# Altium schematic sheet Analog output.SchDoc
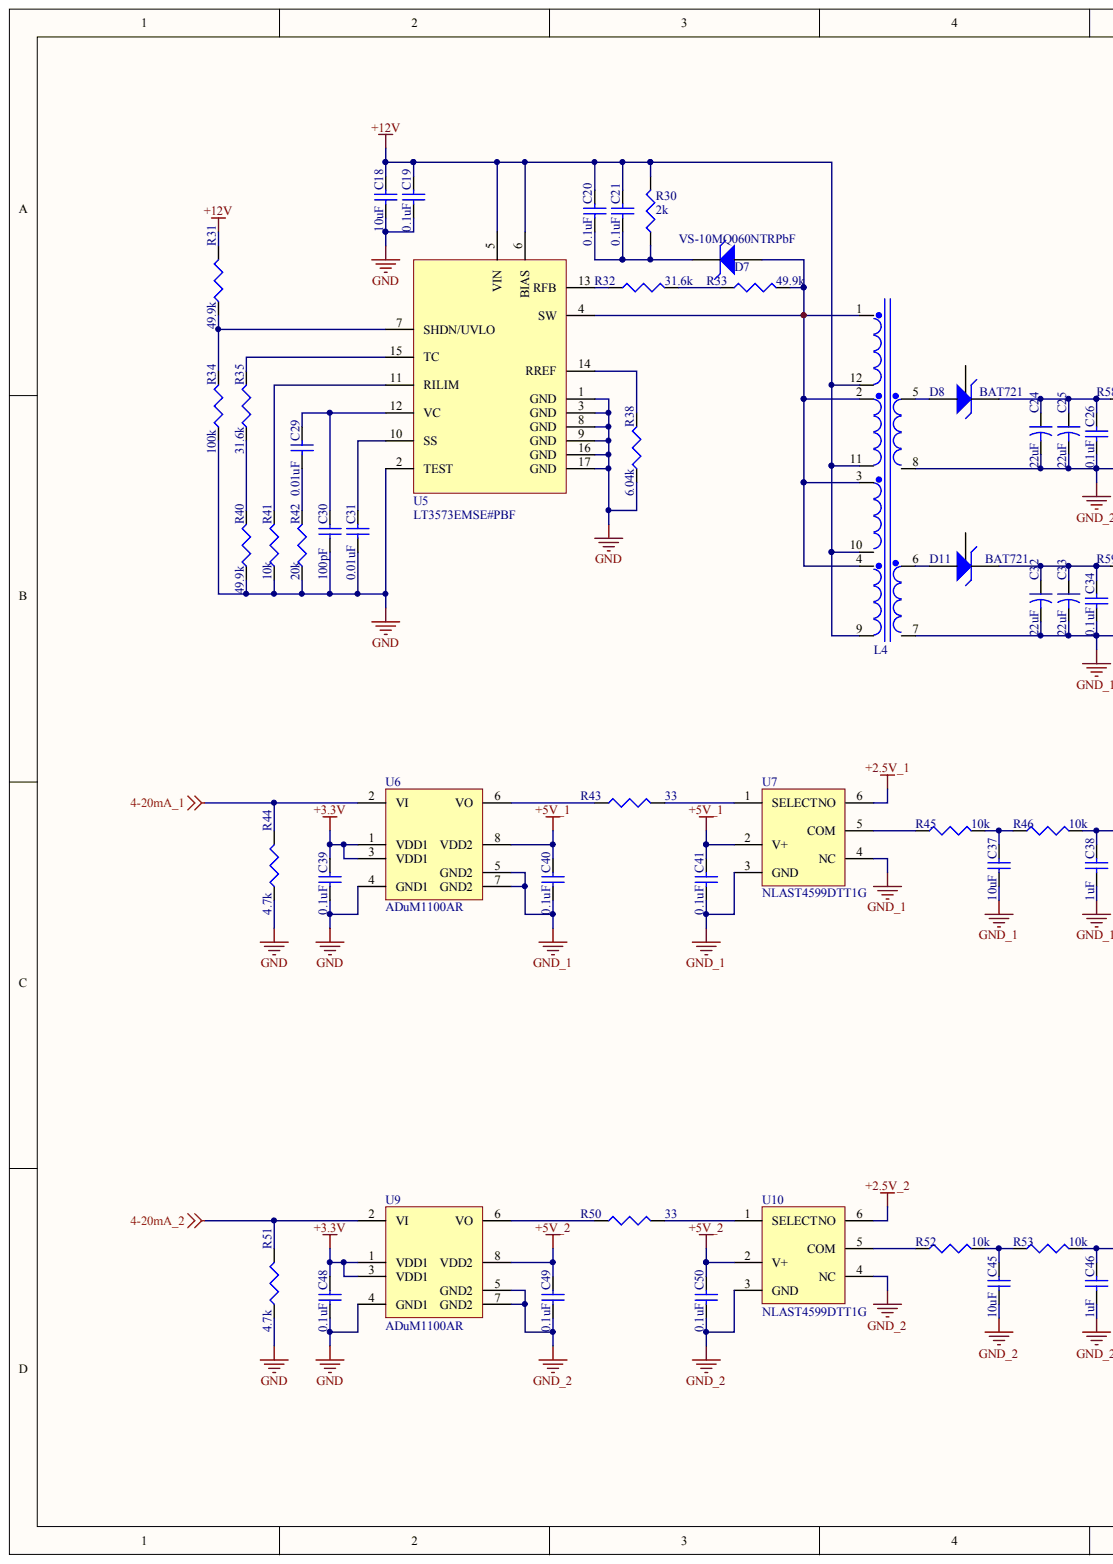
[diagram p0: Analog output.SchDoc, left]
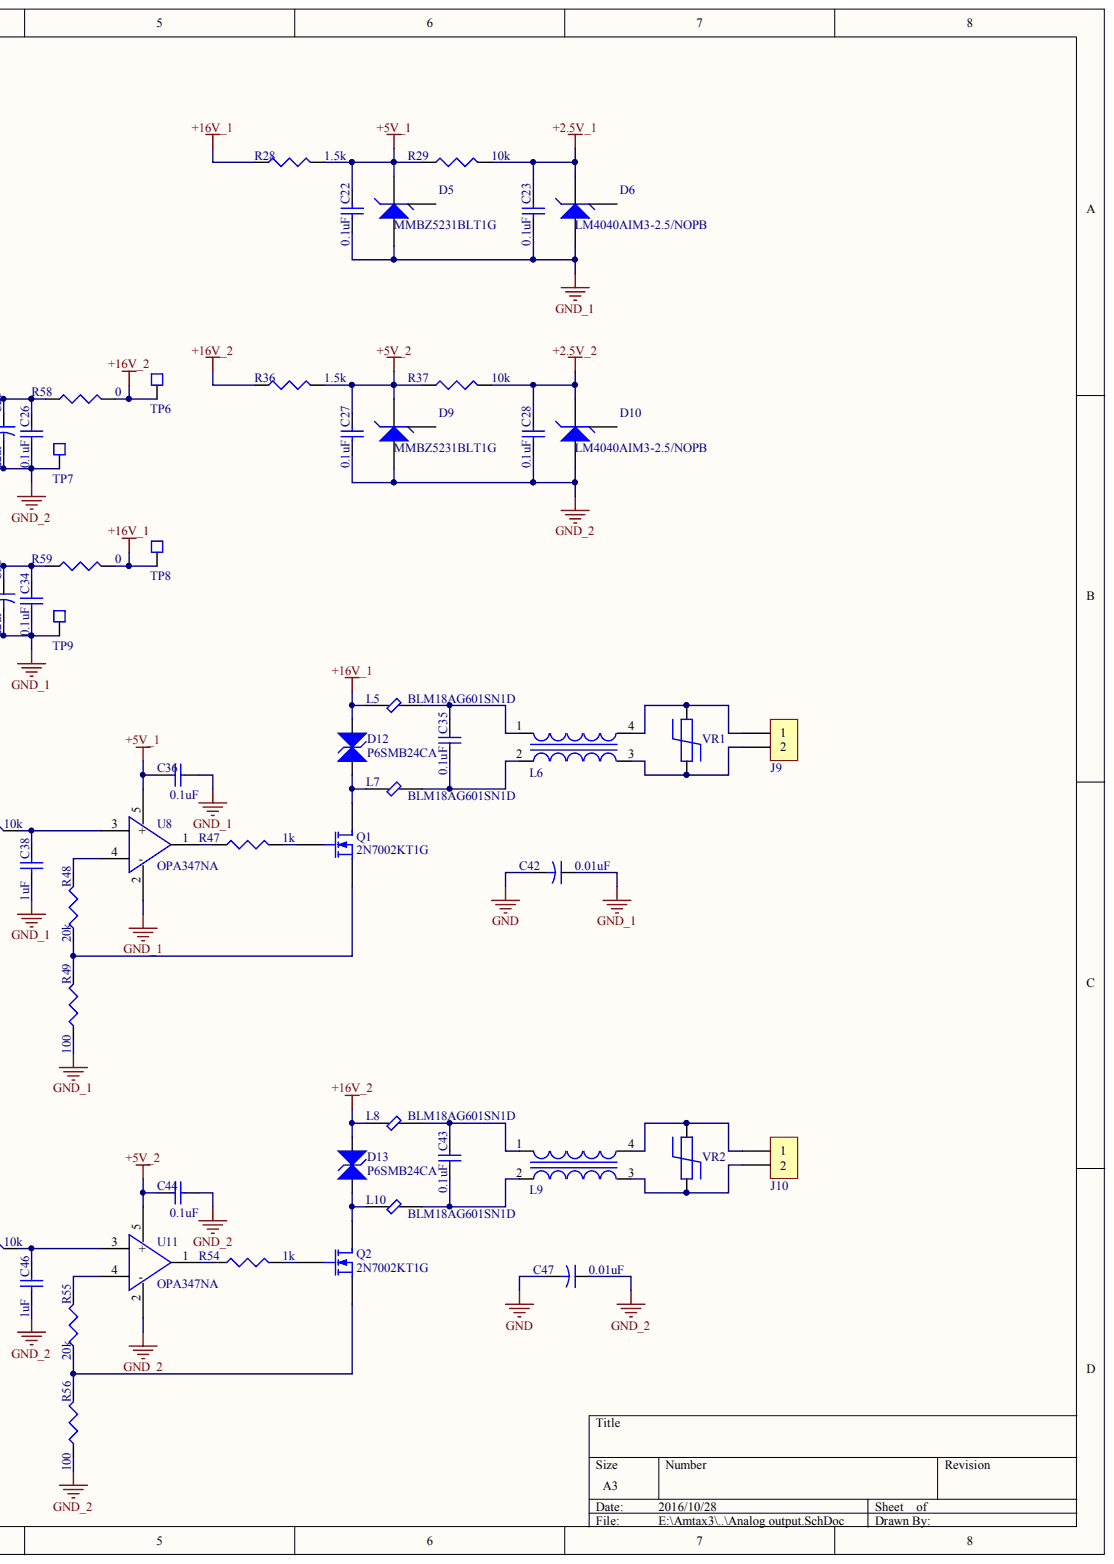
[diagram p1: Analog output.SchDoc, right]

## Parts
C18  value 10uF  [CAP1206(3216)]  (hidden comment: GRM31CR61E106KA12L)
C19  value 0.1uF  [CAP0603(1608)]  (hidden comment: GRM188R71H104KA93D)
C20  value 0.1uF  [CAP0603(1608)]  (hidden comment: GRM188R71H104KA93D)
C21  value 0.1uF  [CAP0603(1608)]  (hidden comment: GRM188R71H104KA93D)
C22  value 0.1uF  [CAP0603(1608)]  (hidden comment: GRM188R71H104KA93D)
C23  value 0.1uF  [CAP0603(1608)]  (hidden comment: GRM188R71H104KA93D)
C24  value 22uF  [CAP1210(3225)]  (hidden comment: GRM32ER71E226KE15L)
C25  value 22uF  [CAP1210(3225)]  (hidden comment: GRM32ER71E226KE15L)
C26  value 0.1uF  [CAP0603(1608)]  (hidden comment: GRM188R71H104KA93D)
C27  value 0.1uF  [CAP0603(1608)]  (hidden comment: GRM188R71H104KA93D)
C28  value 0.1uF  [CAP0603(1608)]  (hidden comment: GRM188R71H104KA93D)
C29  value 0.01uF  [CAP0603(1608)]  (hidden comment: GRM188R71H103KA01D)
C30  value 100pF  [CAP0603(1608)]  (hidden comment: GRM1885C1H101JA01D)
C31  value 0.01uF  [CAP0603(1608)]  (hidden comment: GRM188R71H103KA01D)
C32  value 22uF  [CAP1210(3225)]  (hidden comment: GRM32ER71E226KE15L)
C33  value 22uF  [CAP1210(3225)]  (hidden comment: GRM32ER71E226KE15L)
C34  value 0.1uF  [CAP0603(1608)]  (hidden comment: GRM188R71H104KA93D)
C35  value 0.1uF  [CAP0603(1608)]  (hidden comment: GRM188R71H104KA93D)
C36  value 0.1uF  [CAP0603(1608)]  (hidden comment: GRM188R71H104KA93D)
C37  value 10uF  [CAP1206(3216)]  (hidden comment: GRM31CR61E106KA12L)
C38  value 1uF  [CAP0805(2012)]  (hidden comment: GRM21BR71H105KA12L)
C39  value 0.1uF  [CAP0603(1608)]  (hidden comment: GRM188R71H104KA93D)
C40  value 0.1uF  [CAP0603(1608)]  (hidden comment: GRM188R71H104KA93D)
C41  value 0.1uF  [CAP0603(1608)]  (hidden comment: GRM188R71H104KA93D)
C42  value 0.01uF  [CAP1206(3216)]  (hidden comment: GRJ31BR72J103KWJ1)
C43  value 0.1uF  [CAP0603(1608)]  (hidden comment: GRM188R71H104KA93D)
C44  value 0.1uF  [CAP0603(1608)]  (hidden comment: GRM188R71H104KA93D)
C45  value 10uF  [CAP1206(3216)]  (hidden comment: GRM31CR61E106KA12L)
C46  value 1uF  [CAP0805(2012)]  (hidden comment: GRM21BR71H105KA12L)
C47  value 0.01uF  [CAP1206(3216)]  (hidden comment: GRJ31BR72J103KWJ1)
C48  value 0.1uF  [CAP0603(1608)]  (hidden comment: GRM188R71H104KA93D)
C49  value 0.1uF  [CAP0603(1608)]  (hidden comment: GRM188R71H104KA93D)
C50  value 0.1uF  [CAP0603(1608)]  (hidden comment: GRM188R71H104KA93D)
D5  MMBZ5231BLT1G  [SOT23(MMBZ52xxBLT1G)]
D6  LM4040AIM3-2.5/NOPB  [SOT23(LM4040AIM3-2.5/NOPB)]
D7  VS-10MQ060NTRPbF  [VS-10MQ060NTRPbF]
D8  BAT721  [SOT-23(BAT721S)-F]
D9  MMBZ5231BLT1G  [SOT23(MMBZ52xxBLT1G)]
D10  LM4040AIM3-2.5/NOPB  [SOT23(LM4040AIM3-2.5/NOPB)]
D11  BAT721  [SOT-23(BAT721S)-F]
D12  P6SMB24CA  [P6SMB24CA]
D13  P6SMB24CA  [P6SMB24CA]
J9  691322310002  [WE 3.81mm Pitch Horizontal 2Pin]  (value not drawn)
J10  691322310002  [WE 3.81mm Pitch Horizontal 2Pin]  (value not drawn)
L4  VPH3-0138-R  [VPH3-0138-R]  (value not drawn)
L5  BLM18AG601SN1D  [BLM18B]
L6  CM0805A371R-10  [CM0805A371R-10]  (value not drawn)
L7  BLM18AG601SN1D  [BLM18B]
L8  BLM18AG601SN1D  [BLM18B]
L9  CM0805A371R-10  [CM0805A371R-10]  (value not drawn)
L10  BLM18AG601SN1D  [BLM18B]
Q1  2N7002KT1G  [SOT23(TO-236)]
Q2  2N7002KT1G  [SOT23(TO-236)]
R28  value 1.5k  [RES0603(1608)]  (hidden comment: RK73B1JTTD152J)
R29  value 10k  [RES0603(1608)]  (hidden comment: RK73B1JTTD103J)
R30  value 2k  [RES0603(1608)]  (hidden comment: RK73B1JTTD202J)
R31  value 49.9k  [RES0603(1608)]  (hidden comment: ERJ3EKF4992V)
R32  value 31.6k  [RES0603(1608)]  (hidden comment: ERJ3EKF3162V)
R33  value 49.9k  [RES0603(1608)]  (hidden comment: ERJ3EKF4992V)
R34  value 100k  [RES0603(1608)]  (hidden comment: RK73H1JTTD1003F)
R35  value 31.6k  [RES0603(1608)]  (hidden comment: ERJ3EKF3162V)
R36  value 1.5k  [RES0603(1608)]  (hidden comment: RK73B1JTTD152J)
R37  value 10k  [RES0603(1608)]  (hidden comment: RK73B1JTTD103J)
R38  value 6.04k  [RES0603(1608)]  (hidden comment: ERJ3EKF6041V)
R40  value 49.9k  [RES0603(1608)]  (hidden comment: ERJ3EKF4992V)
R41  value 10k  [RES0603(1608)]  (hidden comment: RK73B1JTTD103J)
R42  value 20k  [RES0603(1608)]  (hidden comment: CRCW060320K0FKEA)
R43  value 33  [RES0603(1608)]  (hidden comment: RK73B1JTTD330J)
R44  value 4.7k  [RES0603(1608)]  (hidden comment: RK73B1JTTD472J)
R45  value 10k  [RES0603(1608)]  (hidden comment: RK73B1JTTD103J)
R46  value 10k  [RES0603(1608)]  (hidden comment: RK73B1JTTD103J)
R47  value 1k  [RES0603(1608)]  (hidden comment: RK73B1JTTD102J)
R48  value 20k  [RES0603(1608)]  (hidden comment: CRCW060320K0FKEA)
R49  value 100  [RES0805(2012)]  (hidden comment: ERA6AEB101V)
R50  value 33  [RES0603(1608)]  (hidden comment: RK73B1JTTD330J)
R51  value 4.7k  [RES0603(1608)]  (hidden comment: RK73B1JTTD472J)
R52  value 10k  [RES0603(1608)]  (hidden comment: RK73B1JTTD103J)
R53  value 10k  [RES0603(1608)]  (hidden comment: RK73B1JTTD103J)
R54  value 1k  [RES0603(1608)]  (hidden comment: RK73B1JTTD102J)
R55  value 20k  [RES0603(1608)]  (hidden comment: CRCW060320K0FKEA)
R56  value 100  [RES0805(2012)]  (hidden comment: ERA6AEB101V)
R58  value 0  [RES0805(2012)]  (hidden comment: RK73Z2ATTD)
R59  value 0  [RES0805(2012)]  (hidden comment: RK73Z2ATTD)
TP6  TEST-1(R)  [TEST PIN]  (value not drawn)
TP7  TEST-1-BK  [TEST PIN]  (value not drawn)
TP8  TEST-1(R)  [TEST PIN]  (value not drawn)
TP9  TEST-1-BK  [TEST PIN]  (value not drawn)
U5  LT3573EMSE#PBF  [MSOP-16(LT3573)]
U6  ADuM1100AR  [SOIC-8(ADuM1100AR)]
U7  NLAST4599DTT1G  [TSOP(NLAST4599DTT1G)]
U8  OPA347NA  [SOT23-5(OPA347NA)]
U9  ADuM1100AR  [SOIC-8(ADuM1100AR)]
U10  NLAST4599DTT1G  [TSOP(NLAST4599DTT1G)]
U11  OPA347NA  [SOT23-5(OPA347NA)]
VR1  V18MLA0805H  [V18MLA0805H]  (value not drawn)
VR2  V18MLA0805H  [V18MLA0805H]  (value not drawn)

## Nets
4-20MA_1: R44.2 U6.2(VI)
4-20MA_2: R51.2 U9.2(VI)
+2.5V_1: C23.2 D6.1 R29.2 U7.6(NO)
+2.5V_2: C28.2 D10.1 R37.2 U10.6(NO)
+3.3V: C39.2 C48.2 U6.1(VDD1) U6.3(VDD1) U9.1(VDD1) U9.3(VDD1)
+5V_1: C22.2 C36.2 C40.2 C41.2 D5.3 R28.2 R29.1 U6.8(VDD2) U7.2(V+) U8.5(+)
+5V_2: C27.2 C44.2 C49.2 C50.2 D9.3 R36.2 R37.1 U9.8(VDD2) U10.2(V+) U11.5(+)
+12V: C18.2 C19.2 C20.2 C21.2 L4.9 L4.10 L4.11 L4.12 R30.2 R31.2 U5.5(VIN) U5.6(BIAS)
+16V_1: D12.2 L5.1 R28.1 R59.2 TP8.1
+16V_2: D13.2 L8.1 R36.1 R58.2 TP6.1
GND: C18.1 C19.1 C30.2 C31.1 C39.1 C42.2 C47.2 C48.1 R34.1 R38.1 R40.1 R41.1 R42.1 R44.1 R51.1 U5.1(GND) U5.2(TEST) U5.3(GND) U5.8(GND) U5.9(GND) U5.16(GND) U5.17(GND) U6.4(GND1) U9.4(GND1)
GND_1: C22.1 C23.1 C32.2 C33.2 C34.1 C36.1 C37.1 C38.1 C40.1 C41.1 C42.1 D5.1 D6.2 L4.7 R49.1 TP9.1 U6.5(GND2) U6.7(GND2) U7.3(GND) U7.4(NC) U8.2
GND_2: C24.2 C25.2 C26.1 C27.1 C28.1 C44.1 C45.1 C46.1 C47.1 C49.1 C50.1 D9.1 D10.2 L4.8 R56.1 TP7.1 U9.5(GND2) U9.7(GND2) U10.3(GND) U10.4(NC) U11.2
NetC20_1: C20.1 C21.1 D7.2 R30.1
NetC24_1: C24.1 C25.1 C26.2 D8.3 R58.1
NetC29_1: C29.1 R42.2
NetC29_2: C29.2 C30.1 U5.12(VC)
NetC31_2: C31.2 U5.10(SS)
NetC32_1: C32.1 C33.1 C34.2 D11.3 R59.1
NetC35_1: C35.1 L6.2 L7.2
NetC35_2: C35.2 L5.2 L6.1
NetC37_2: C37.2 R45.2 R46.1
NetC38_2: C38.2 R46.2 U8.3
NetC43_1: C43.1 L9.2 L10.2
NetC43_2: C43.2 L8.2 L9.1
NetC45_2: C45.2 R52.2 R53.1
NetC46_2: C46.2 R53.2 U11.3
NetD7_1: D7.1 L4.1 L4.2 L4.3 L4.4 R33.2 U5.4(SW)
NetD8_1: D8.1 L4.5
NetD11_1: D11.1 L4.6
NetD12_1: D12.1 L7.1 Q1.3
NetD13_1: D13.1 L10.1 Q2.3
NetJ9_1: J9.1 L6.4 VR1.2
NetJ9_2: J9.2 L6.3 VR1.1
NetJ10_1: J10.1 L9.4 VR2.2
NetJ10_2: J10.2 L9.3 VR2.1
NetQ1_1: Q1.1 R47.2
NetQ1_2: Q1.2 R48.1 R49.2
NetQ2_1: Q2.1 R54.2
NetQ2_2: Q2.2 R55.1 R56.2
NetR31_1: R31.1 R34.2 U5.7(SHDN/UVLO)
NetR32_1: R32.1 U5.13(RFB)
NetR32_2: R32.2 R33.1
NetR35_1: R35.1 R40.2
NetR35_2: R35.2 U5.15(TC)
NetR38_2: R38.2 U5.14(RREF)
NetR41_2: R41.2 U5.11(RILIM)
NetR43_1: R43.1 U6.6(VO)
NetR43_2: R43.2 U7.1(SELECT)
NetR45_1: R45.1 U7.5(COM)
NetR47_1: R47.1 U8.1
NetR48_2: R48.2 U8.4(-)
NetR50_1: R50.1 U9.6(VO)
NetR50_2: R50.2 U10.1(SELECT)
NetR52_1: R52.1 U10.5(COM)
NetR54_1: R54.1 U11.1
NetR55_2: R55.2 U11.4(-)

Not connected: D5 2; D6 3; D8 2; D9 2; D10 3; D11 2

## Library data of the parts
C18 C37 C45 = CAP1206 10MFD 25VDC X5R: Ceramic Capacitor CAP1206 10MFD 25VDC X5R; Vendor Name: MURATA; Component Kind: Standard; MPN: GRM31CR61E106KA12L; Library Name: dosing board.SchLib
C19-C23 C26-C28 C34-C36 C39-C41 C43 C44 C48-C50 = CAP0603 0.1MFD 50VDC X7R: Ceramic Capacitor CAP0603 0.1MFD 50VDC X7R; Vendor Name: MURATA; Component Kind: Standard; MPN: GRM188R71H104KA93D; Library Name: dosing board.SchLib
C24 C25 C32 C33 = CAP1210 22MFD 25VDC X7R: Ceramic Capacitor CAP1210 22MFD 25VDC X7R; Vendor Name: MURATA; Component Kind: Standard; MPN: GRM32ER71E226KE15L; Library Name: dosing board.SchLib
C29 C31 = CAP0603 0.01MFD 50VDC X7R: Ceramic Capacitor CAP0603 0.01MFD 50VDC X7R; Vendor Name: MURATA; Component Kind: Standard; MPN: GRM188R71H103KA01D; Library Name: dosing board.SchLib
C30 = CAP0603 100PFD 50VDC COG: Ceramic Capacitor CAP0603 100PFD 50VDC COG; Vendor Name: MURATA; Component Kind: Standard; MPN: GRM1885C1H101JA01D; Library Name: dosing board.SchLib
C38 C46 = CAP0805 1MFD 50VDC X7R: Ceramic Capacitor CAP0805 1MFD 50VDC X7R; Vendor Name: MURATA; Component Kind: Standard; MPN: GRM21BR71H105KA12L; Library Name: dosing board.SchLib
C42 C47 = CAP1206 0.01MFD 630VDC X7R: Ceramic Capacitor CAP1206 0.01MFD 630VDC X7R; Vendor Name: MURATA; Component Kind: Standard; MPN: GRJ31BR72J103KWJ1; Library Name: dosing board.SchLib
D5 D9 = MMBZ5231BLT1G: Zener Voltage Regulators; Vendor Name: ON SEMICONDUCTOR; Component Kind: Standard; MPN: MMBZ5231BLT1G; Library Name: dosing board.SchLib
D6 D10 = LM4040AIM3-2.5/NOPB: N/-Q1 Precision Micropower Shunt Voltage Reference; Vendor Name: Texas Instruments; Component Kind: Standard; MPN: LM4040AIM3-2.5/NOPB; Library Name: dosing board.SchLib
D7 = VS-10MQ060NTRPbF: High Performance Schottky Rectifier, 1 A; Vendor Name: VISHAY; Component Kind: Standard; MPN: VS-10MQ060NTRPbF; Library Name: dosing board.SchLib
D8 D11 = BAT721: Single Schottky Diode, V=40V, I=200mA; Vendor Name: NXP; Component Kind: Standard; MPN: BAT721; Library Name: dosing board.SchLib
D12 D13 = P6SMB24CA: 600 Watt Peak Power Zener  Transient Voltage  Suppressors; MPN: P6SMB24CAT3G; Vendor Name: On Semi; Component Kind: Standard; Library Name: dosing board.SchLib
J9 J10 = 691322310002: 2Pin，3.81mm Pitch，Connector; Vendor Name: WE; Component Kind: Standard; MPN: 691322310002; Library Name: dosing board.SchLib
L4 = VPH3-0138-R: VERSA-PAC Inductors and Transformers; Vendor Name: Eaton; Component Kind: Standard; MPN: VPH3-0138-R; Library Name: dosing board.SchLib
L5 L7 L8 L10 = BLM18AG601SN1D: Ferrite Bead for General  Signal Lines; Vendor Name: MURATA; Component Kind: Standard; MPN: BLM18AG601SN1D; Library Name: dosing board.SchLib
L6 L9 = CM0805A371R-10: Common Mode Choke Coil 370ohm, 100mA; Vendor Name: LAIRD TECHNOLOGIES; Component Kind: Standard; MPN: CM0805A371R-10; Library Name: dosing board.SchLib
Q1 Q2 = 2N7002K: N-Channel MOSFET; Vendor Name: ON SEMI; Component Kind: Standard; MPN: 2N7002KT1G; Library Name: dosing board.SchLib
R28 R36 = RES0603, 1.5K OHM 1/10W 5%: Vendor Name: KOA; Component Kind: Standard; Description: RES0603, 1.5K OHM 1/10W 5%; MPN: RK73B1JTTD152J; Library Name: dosing board.SchLib
R29 R37 R41 R45 R46 R52 R53 = RES0603, 10K OHM 1/10W 5%: Vendor Name: KOA; Component Kind: Standard; Description: RES0603, 10K OHM 1/10W 5%; MPN: RK73B1JTTD103J; Library Name: dosing board.SchLib
R30 = RES0603, 2K OHM 1/10W 5%: Vendor Name: KOA; Component Kind: Standard; Description: RES0603, 2K OHM 1/10W 5%; MPN: RK73B1JTTD202J; Library Name: dosing board.SchLib
R31 R33 R40 = RES0603, 49.9k OHM 1/10W 1%: RES0603,49.9k OHM 1/10W 1%; Vendor Name: Panasonic; Component Kind: Standard; MPN: ERJ3EKF4992V; Library Name: dosing board.SchLib
R32 R35 = RES0603, 31.6k OHM 1/10W 1%: RES0603,31.6k OHM 1/10W 1%; Vendor Name: Panasonic; Component Kind: Standard; MPN: ERJ3EKF3162V; Library Name: dosing board.SchLib
R34 = RES0603, 100k OHM 1/10W 1%: Vendor Name: KOA; Component Kind: Standard; Description: RES0603, 100k OHM 1/10W 1%; MPN: RK73H1JTTD1003F; Library Name: dosing board.SchLib
R38 = RES0603, 6.04k OHM 1/10W 1%: RES0603,6.04k OHM 1/10W 1%; Vendor Name: Panasonic; Component Kind: Standard; MPN: ERJ3EKF6041V; Library Name: dosing board.SchLib
R42 R48 R55 = RES0603, 20K OHM 1/10W 5%: RES0603, 20K OHM 1/10W 1%; Vendor Name: VISHAY; Component Kind: Standard; MPN: CRCW060320K0FKEA; Library Name: dosing board.SchLib
R43 R50 = RES0603, 33 OHM 1/10W 5%: RES0603, 33OHM 1/10W 5%; Vendor Name: KOA; Component Kind: Standard; MPN: RK73B1JTTD330J; Library Name: dosing board.SchLib
R44 R51 = RES0603, 4.7K OHM 1/10W 5%: Vendor Name: KOA; Component Kind: Standard; Description: RES0603, 4.7K OHM 1/10W 5%; MPN: RK73B1JTTD472J; Library Name: dosing board.SchLib
R47 R54 = RES0603, 1K OHM 1/10W 5%: Vendor Name: KOA; Component Kind: Standard; Description: RES0603, 1K OHM 1/10W 5%; MPN: RK73B1JTTD102J; Library Name: dosing board.SchLib
R49 R56 = RES0805, 100 OHM 1/8W 0.1%: Vendor Name: PANASONIC; Component Kind: Standard; Description: RES0805, 100 OHM 1/8W 0.1%; MPN: ERA6AEB101V; Library Name: dosing board.SchLib
R58 R59 = RES0805, 0 OHM: Vendor Name: KOA; Component Kind: Standard; Description: RES0805, 0 OHM; MPN: RK73Z2ATTD; Library Name: dosing board.SchLib
TP6 TP8 = TEST-1-R: Test Pin Red; Vendor Name: MULTICOMP; Component Kind: Standard; MPN: TEST-1(R); Library Name: dosing board.SchLib
TP7 TP9 = TEST-1-BK: Test Pin Black; Vendor Name: MULTICOMP; Component Kind: Standard; MPN: TEST-1-BK; Library Name: dosing board.SchLib
U5 = LT3573EMSE#PBF: Isolated Flyback Converter without an Opto-Coupler; Vendor Name: Linear; Component Kind: Standard; MPN: LT3573EMSE#PBF; Library Name: dosing board.SchLib
U6 U9 = ADuM1100AR: iCoupler Digital Isolator; Vendor Name: Analog Device; Component Kind: Standard; MPN: ADuM1100AR; Library Name: dosing board.SchLib
U7 U10 = NLAST4599DTT1G: Low Voltage Single Supply SPDT Analog Switch; Vendor Name: On Semiconductor; Component Kind: Standard; MPN: NLAST4599DTT1G; Library Name: dosing board.SchLib
U8 U11 = OPA347NA: microPower, Rail-to-Rail  Operational Amplifiers; Vendor Name: Texas Instruments; Component Kind: Standard; MPN: OPA347NA; Library Name: dosing board.SchLib
VR1 VR2 = V18MLA0805H: Surface Mount Multilayer Varistors (MLVs) > ML Series; Vendor Name: Littelfuse; Component Kind: Standard; MPN: V18MLA0805H; Library Name: dosing board.SchLib
